# Altium schematic sheet 19WSC_MCU_190726.SchDoc(19WSC_MCU_190726)
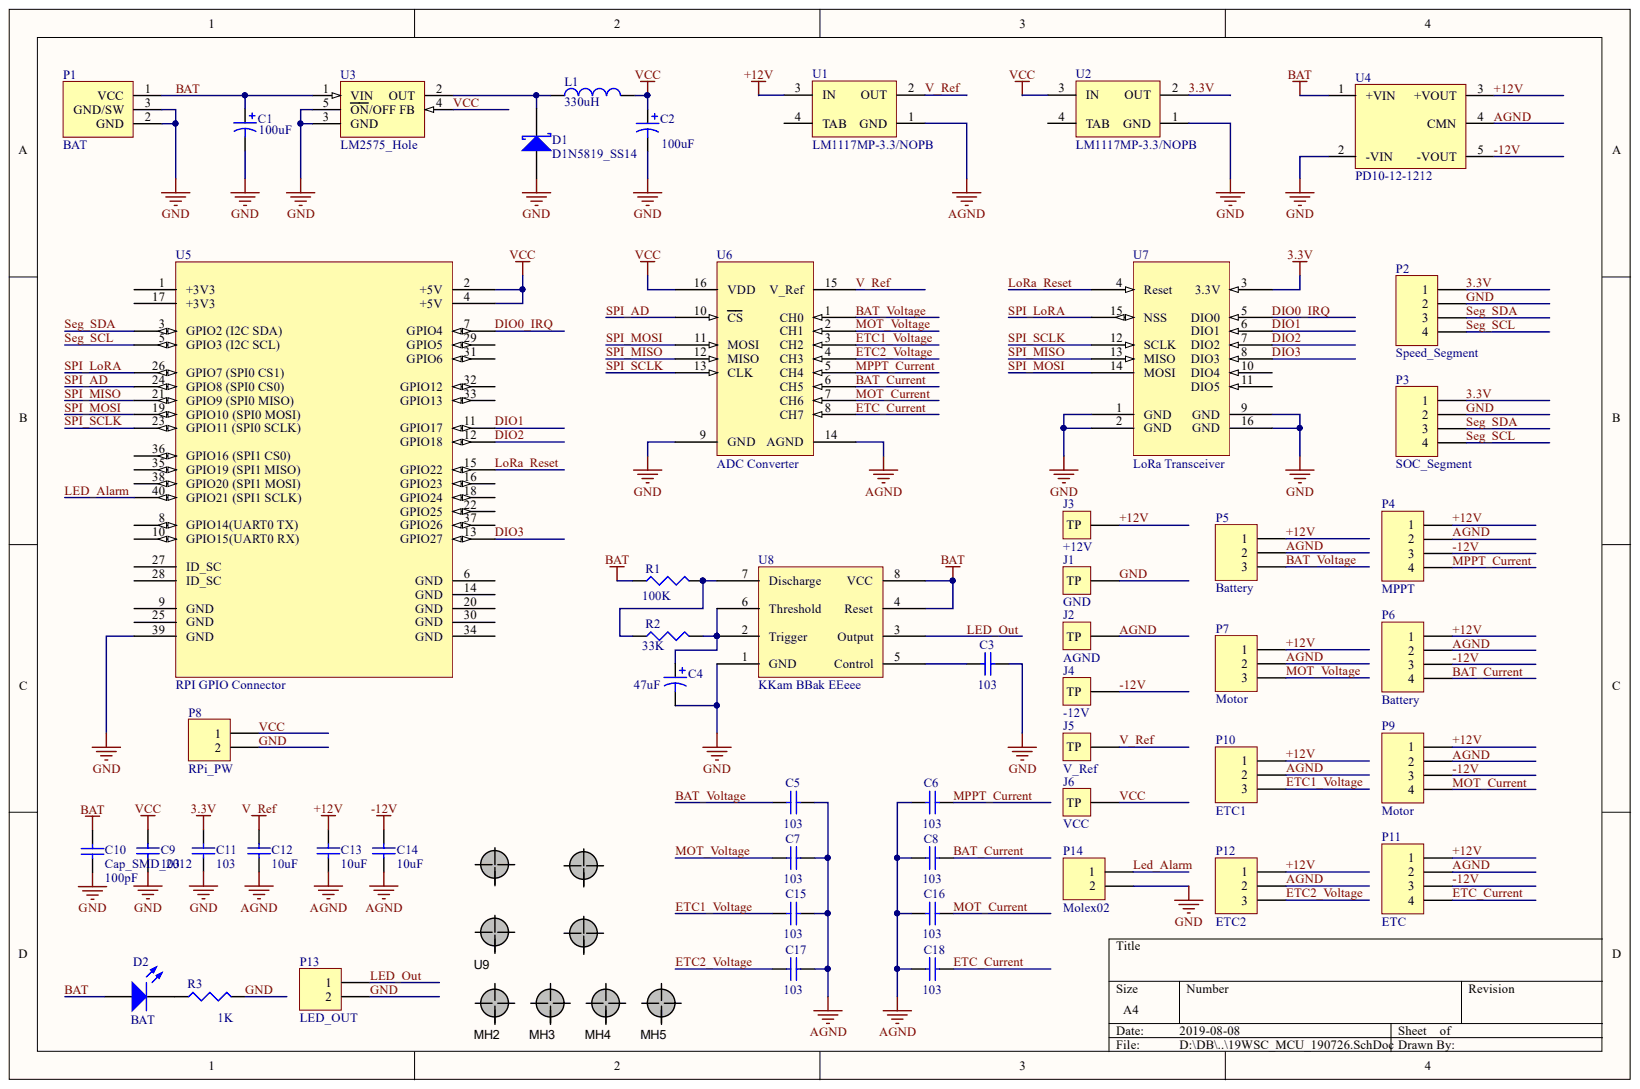
[diagram p0: 19WSC_MCU_190726.SchDoc]

## Parts
C1  value 100uF  [Cap_Pol_SMD_10]  (hidden comment: Cap_Pol_100)
C2  value 100uF  [Cap_Pol_SMD_10]  (hidden comment: Cap_Pol_100)
C3  Cap_SMD_2012  value 103  [CAPC2012N]
C4  value 47uF  [Cap_Pol_SMD_06_3]  (hidden comment: Cap_Pol_10)
C5  Cap_SMD_2012  value 103  [CAPC2012N]
C6  Cap_SMD_2012  value 103  [CAPC2012N]
C7  Cap_SMD_2012  value 103  [CAPC2012N]
C8  Cap_SMD_2012  value 103  [CAPC2012N]
C9  Cap_SMD_2012  value 103  [CAPC2012N]
C10  Cap_SMD_2012  value 100pF  [CAPC2012N]
C11  Cap_SMD_2012  value 103  [CAPC2012N]
C12  Cap_SMD_2012  value 10uF  [CAPC2012N]
C13  Cap_SMD_2012  value 10uF  [CAPC2012N]
C14  Cap_SMD_2012  value 10uF  [CAPC2012N]
C15  Cap_SMD_2012  value 103  [CAPC2012N]
C16  Cap_SMD_2012  value 103  [CAPC2012N]
C17  Cap_SMD_2012  value 103  [CAPC2012N]
C18  Cap_SMD_2012  value 103  [CAPC2012N]
D1  D1N5819_SS14  [Diode_2002]
D2  BAT  [Diode_2012]
J1  GND  [Test_Point]
J2  AGND  [Test_Point]
J3  +12V  [Test_Point]
J4  -12V  [Test_Point]
J5  V_Ref  [Test_Point]
J6  VCC  [Test_Point]
L1  value 330uH  [SMD_Inductor_680]  (hidden comment: Inductor_680)
MH2  Mount_Hole_35  [PCB_Hole_3.5]  (value not drawn)  (no BOM)
MH3  Mount_Hole_35  [PCB_Hole_3.5]  (value not drawn)  (no BOM)
MH4  Mount_Hole_35  [PCB_Hole_3.5]  (value not drawn)  (no BOM)
MH5  Mount_Hole_35  [PCB_Hole_3.5]  (value not drawn)  (no BOM)
P1  BAT  [DC_Jack]
P2  Speed_Segment  [Molex_04]
P3  SOC_Segment  [Molex_04]
P4  MPPT  [Molex_04]
P5  Battery  [Molex_03]
P6  Battery  [Molex_04]
P7  Motor  [Molex_03]
P8  RPi_PW  [Molex_02]
P9  Motor  [Molex_04]
P10  ETC1  [Molex_03]
P11  ETC  [Molex_04]
P12  ETC2  [Molex_03]
P13  LED_OUT  [Molex_02]
P14  Molex02  [Molex_02]
R1  value 100K  [RESC2012N]  (hidden comment: Res_2012)
R2  value 33K  [RESC2012N]  (hidden comment: Res_2012)
R3  value 1K  [RESC2012N]  (hidden comment: Res_2012)
U1  LM1117MP-3.3/NOPB  [LM1117MP-3.3]
U2  LM1117MP-3.3/NOPB  [LM1117MP-3.3]
U3  LM2575_Hole  [LM2575_Hole_Hit]
U4  PD10-12-1212  [PD10-12-1212]
U5  RPI GPIO Connector  Accessory Type: Connector  [40P_PCB_SOCKET_BRD_MNT]
U6  ADC Converter  [DIP_16]
U7  LoRa Transceiver  [LoRa]
U8  KKam BBak EEeee  [SOP_8_M]
U9  RPi3B+_Hole  [RPi3B_Hole]  (value not drawn)  (no BOM)

## Nets
3.3V: C11.2 P2.1 P3.1 U2.2(OUT) U7.3(3.3V)
+12V: C13.2 J3.1 P4.1 P5.1 P6.1 P7.1 P9.1 P10.1 P11.1 P12.1 U1.3(IN) U4.3(+VOUT)
-12V: C14.2 J4.1 P4.3 P6.3 P9.3 P11.3 U4.5(-VOUT)
AGND: C5.2 C6.1 C7.2 C8.1 C12.1 C13.1 C14.1 C15.2 C16.1 C17.2 C18.1 J2.1 P4.2 P5.2 P6.2 P7.2 P9.2 P10.2 P11.2 P12.2 U1.1(GND) U4.4(CMN) U6.14(AGND)
BAT: C1.1 C10.2 D2.1 P1.1 R1.1 U3.1(VIN) U4.1(+VIN) U8.4(Reset) U8.8(VCC)
BAT_CURRENT: C8.2 P6.4 U6.6(CH5)
BAT_VOLTAGE: C5.1 P5.3 U6.1(CH0)
DIO0_IRQ: U5.7(GPIO4) U7.5(DIO0)
DIO1: U5.11(GPIO17) U7.6(DIO1)
DIO2: U5.12(GPIO18) U7.7(DIO2)
DIO3: U5.13(GPIO27) U7.8(DIO3)
ETC1_VOLTAGE: C15.1 P10.3 U6.3(CH2)
ETC2_VOLTAGE: C17.1 P12.3 U6.4(CH3)
ETC_CURRENT: C18.2 P11.4 U6.8(CH7)
GND: C1.2 C2.2 C3.2 C4.2 C9.1 C10.1 C11.1 D1.1 J1.1 P1.2 P1.3 P2.2 P3.2 P8.2 P13.2 P14.2 R3.2 U2.1(GND) U3.3(GND) U3.5(ON/OFF) U4.2(-VIN) U5.39(GND) U6.9(GND) U7.1(GND) U7.2(GND) U7.9(GND) U7.16(GND) U8.1(GND)
LED_ALARM: P14.1 U5.40(GPIO21_(SPI1_SCLK))
LED_OUT: P13.1 U8.3(Output)
LORA_RESET: U5.15(GPIO22) U7.4(Reset)
MOT_CURRENT: C16.2 P9.4 U6.7(CH6)
MOT_VOLTAGE: C7.1 P7.3 U6.2(CH1)
MPPT_CURRENT: C6.2 P4.4 U6.5(CH4)
SEG_SCL: P2.4 P3.4 U5.5(GPIO3_(I2C_SCL))
SEG_SDA: P2.3 P3.3 U5.3(GPIO2_(I2C_SDA))
SPI_AD: U5.24(GPIO8_(SPI0_CS0)) U6.10(CS)
SPI_LORA: U5.26(GPIO7_(SPI0_CS1)) U7.15(NSS)
SPI_MISO: U5.21(GPIO9_(SPI0_MISO)) U6.12(MISO) U7.13(MISO)
SPI_MOSI: U5.19(GPIO10_(SPI0_MOSI)) U6.11(MOSI) U7.14(MOSI)
SPI_SCLK: U5.23(GPIO11_(SPI0_SCLK)) U6.13(CLK) U7.12(SCLK)
V_REF: C12.2 J5.1 U1.2(OUT) U6.15(V_Ref)
VCC: C2.1 C9.2 J6.1 L1.2 P8.1 U2.3(IN) U3.4(FB) U5.2(+5V) U5.4(+5V) U6.16(VDD)
NetC3_1: C3.1 U8.5(Control)
NetC4_1: C4.1 R2.2 U8.2(Trigger) U8.6(Threshold)
NetD1_2: D1.2 L1.1 U3.2(OUT)
NetD2_2: D2.2 R3.1
NetR1_2: R1.2 R2.1 U8.7(Discharge)

Not connected: MH2 1; MH3 1; MH4 1; MH5 1; U1 4(TAB); U2 4(TAB); U5 1(+3V3) 6(GND) 8(GPIO14(UART0_TX)) 9(GND) 10(GPIO15(UART0_RX)) 14(GND) 16(GPIO23) 17(+3V3) 18(GPIO24) 20(GND) 22(GPIO25) 25(GND) 27(ID_SC) 28(ID_SC) 29(GPIO5) 30(GND) 31(GPIO6) 32(GPIO12) 33(GPIO13) 34(GND) 35(GPIO19_(SPI1_MISO)) 36(GPIO16_(SPI1_CS0)) 37(GPIO26) 38(GPIO20_(SPI1_MOSI)); U7 10(DIO4) 11(DIO5); U9 1 2 3 4

## Library data of the parts
C1 C2 = Cap_Pol_100: Polarized Capacitor (SMD 13); Component Kind: Standard; LatestRevisionDate: 17-Jul-2002; LatestRevisionNote: Re-released for DXP Platform.; PackageReference: POLAR0.8; Published: 8-Jun-2000; Library Name: KSH_Library_WSC.IntLib; Publisher: Altium Limited
C3 C5-C18 = Cap_SMD_2012: Capacitor; Component Kind: Standard; LatestRevisionDate: 17-Jul-2002; LatestRevisionNote: Re-released for DXP Platform.; PackageReference: RAD-0.3; Published: 8-Jun-2000; Library Name: KSH_Library_WSC.IntLib; Publisher: Altium Limited
C4 = Cap_Pol_10: Polarized Capacitor (SMD 6.3); Component Kind: Standard; LatestRevisionDate: 17-Jul-2002; LatestRevisionNote: Re-released for DXP Platform.; PackageReference: POLAR0.8; Published: 8-Jun-2000; Library Name: KSH_Library_WSC.IntLib; Publisher: Altium Limited
D1 = D1N5819_SS14: Schottky Rectifier; Note: Pin 2 is not connected and not drawn.; Component Kind: Standard; ComponentLink1Description: Manufacturer Link; ComponentLink1URL: http://www.infineon.com/; ComponentLink2Description: Datasheet; ComponentLink2URL: http://www.infineon.com/upload/Document/cmc_upload/documents/009/363/bat17series.pdf; DatasheetDocument: Oct-1999; PackageDocument: Sep-2001; PackageReference: SOT-23; Published: 21-Mar-2003; Library Name: KSH_Library_WSC.IntLib; Publisher: Altium Limited
D2 = LED_2012: Typical INFRARED GaAs LED; Component Kind: Standard; LatestRevisionDate: 08-Jul-2005; LatestRevisionNote: Mech Layer 1 removed.; PackageReference: LED-0; Published: 8-Jun-2000; Library Name: KSH_Library_WSC.IntLib; Publisher: Altium Limited
J1-J6 = Test_Point: Test Point GND; Component Kind: Standard; Library Name: KSH_Library_WSC.IntLib
L1 = Inductor_680: Code_IEC: 1005; Code_JEITA: 0402; Component Kind: Standard; Description: Inductor_680; LatestRevisionDate: 17-Jul-2002; LatestRevisionNote: Re-released for DXP Platform.; PackageReference: 0402-A; Published: 8-Jun-2000; Library Name: KSH_Library_WSC.IntLib; Publisher: Altium Limited
MH2-MH5 = Mount_Hole_3: Mount Hole 3.5mm; Library Name: KSH_Library_WSC.IntLib
P1 = Battery_Con: Header, 2-Pin; Component Kind: Standard; LatestRevisionDate: 17-Jul-2002; LatestRevisionNote: Re-released for DXP Platform.; Library Name: KSH_Library_WSC.IntLib; Publisher: Altium Limited
P2-P4 P6 P9 P11 = Molex04: Header, 4-Pin; Component Kind: Standard; LatestRevisionDate: 17-Jul-2002; LatestRevisionNote: Re-released for DXP Platform.; Library Name: KSH_Library_WSC.IntLib; Publisher: Altium Limited
P5 P7 P10 P12 = Molex03: Header, 3-Pin; Component Kind: Standard; LatestRevisionDate: 17-Jul-2002; LatestRevisionNote: Re-released for DXP Platform.; Library Name: KSH_Library_WSC.IntLib; Publisher: Altium Limited
P8 P13 P14 = Molex02: Header, 2-Pin; Component Kind: Standard; LatestRevisionDate: 17-Jul-2002; LatestRevisionNote: Re-released for DXP Platform.; Library Name: KSH_Library_WSC.IntLib; Publisher: Altium Limited
R1-R3 = Res_2012: Resistor; Component Kind: Standard; LatestRevisionDate: 04-Mar-2005; LatestRevisionNote: PCB Footprint replaced.; PackageReference: J1-0603; Published: 8-Jun-2000; Library Name: KSH_Library_WSC.IntLib; Publisher: Altium Limited
U1 U2 = LM1117MP-3.3/NOPB: 800mA Low-Dropout Linear Regulator, 4-pin SOT-223, Pb-Free; Manufacturer: National Semiconductor; CompType: LDO IC; PackageTopMarking: XYTT*N05A; PowerWise: N; Code_IPC: SOT223-4; Code_JEDEC: TO-261-AA; Component Kind: Standard; ComponentLink1Description: LM1117 Datasheet; ComponentLink1URL: http://www.national.com/ds/LM/LM1117.pdf; ComponentLink2Description: LM1117 Web Page; ComponentLink2URL: http://www.national.com/pf/LM/LM1117.html; ComponentLink3Description: MP04A Package Page; ComponentLink3URL: http://www.national.com/packaging/folders/mp04a.html; DatasheetVersion: Apr-2006; PackageDescription: Molded SOT-223, 6.50 x 3.56 x 1.6mm Body, 4 Lead, 2.3mm Pitch; PackageReference: MP04A; PackageVersion: revB, Apr-2003; PartNumber: LM1117MP-3.3/NOPB; PinCount: 4; Published: 1-Mar-2010; RoHS: Y; Supplier 1: Digi-Key; Supplier 2: Digi-Key; Supplier 3: Digi-Key; Supplier 4: Farnell; Supplier Part Number 1: LM1117MP-3.3TR-ND; Supplier Part Number 2: LM1117MP-3.3CT-ND; Supplier Part Number 3: LM1117MP-3.3DKR-ND; Supplier Part Number 4: 1469054; Library Name: KSH_Library_WSC.IntLib; Publisher: Altium Limited
U3 = LM2575_Hole: Voltage Regulator; Code_JEDEC: TS-001-AA; Component Kind: Standard; ComponentLink1Description: Manufacturer Link; ComponentLink1URL: http://www.onsemi.com/site/products/taxonomy/0,4438,503,00.html; ComponentLink2Description: Datasheet; ComponentLink2URL: http://www.onsemi.com/pub/Collateral/LM2575-D.PDF; DatasheetVersion: Jul-1999; PackageDescription: TO, Thru-Hole, Vertical, Heatsink Mounted; 5 In-Line Leads; Pitch 1.70 mm; PackageReference: 314D-03; PackageVersion: Jul-1999; Published: 15-Apr-2002; Library Name: KSH_Library_WSC.IntLib; Publisher: Altium Limited
U4 = PD10-12-1212: DC-DC Converter Module; Component Kind: Standard; Published: 02-Sep-2017; Library Name: KSH_Library_WSC.IntLib; Publisher: NSTECH
U5 = RPi3B+: GPIO Header for Raspberry Pi A+/B+/Pi 2/Pi 3 - 2x20; Manufacturer: SparkFun Electronics; Manufacturer Part Number: PRT-14017; Nils Minor: author; nilsminor@web.de: mail; Category: Development Boards, Kits, Programmers; Component Kind: Standard; ComponentLink1Description: https://cdn.sparkfun.com/datasheets/Dev/RaspberryPi/12313.pdf; ComponentLink1URL: https://cdn.sparkfun.com/datasheets/Dev/RaspberryPi/12313.pdf; For Use With/Related Products: Raspberry Pi; Part Status: Active; Pricing 1: 1=1.84 (EUR); RoHS: RoHS Compliant; Stock 1: 150; Library Name: KSH_Library_WSC.IntLib
U6 = MCP3208: Manufacturer: SparkFun Electronics; Manufacturer Part Number: PRT-14017; Accessory Type: Connector; For Use With/Related Products: Raspberry Pi; Nils Minor: author; nilsminor@web.de: mail; Category: Development Boards, Kits, Programmers; Component Kind: Standard; ComponentLink1Description: https://cdn.sparkfun.com/datasheets/Dev/RaspberryPi/12313.pdf; ComponentLink1URL: https://cdn.sparkfun.com/datasheets/Dev/RaspberryPi/12313.pdf; Description: ADC Converter; Part Status: Active; Pricing 1: 1=1.84 (EUR); RoHS: RoHS Compliant; Stock 1: 150; Library Name: KSH_Library_WSC.IntLib
U7 = SX1278: Manufacturer: SparkFun Electronics; Manufacturer Part Number: PRT-14017; Accessory Type: Connector; For Use With/Related Products: Raspberry Pi; Nils Minor: author; nilsminor@web.de: mail; Category: Development Boards, Kits, Programmers; Component Kind: Standard; ComponentLink1Description: https://cdn.sparkfun.com/datasheets/Dev/RaspberryPi/12313.pdf; ComponentLink1URL: https://cdn.sparkfun.com/datasheets/Dev/RaspberryPi/12313.pdf; Description: LoRa Transceiver; Part Status: Active; Pricing 1: 1=1.84 (EUR); RoHS: RoHS Compliant; Stock 1: 150; Library Name: KSH_Library_WSC.IntLib
U8 = SE555: Manufacturer: SparkFun Electronics; Manufacturer Part Number: PRT-14017; Accessory Type: Connector; For Use With/Related Products: Raspberry Pi; Nils Minor: author; nilsminor@web.de: mail; Category: Development Boards, Kits, Programmers; Component Kind: Standard; ComponentLink1Description: https://cdn.sparkfun.com/datasheets/Dev/RaspberryPi/12313.pdf; ComponentLink1URL: https://cdn.sparkfun.com/datasheets/Dev/RaspberryPi/12313.pdf; Description: KKam BBak EEeee; Part Status: Active; Pricing 1: 1=1.84 (EUR); RoHS: RoHS Compliant; Stock 1: 150; Library Name: KSH_Library_WSC.IntLib
U9 = RPi3B+_Hole: Description: RPi3B+_Hole; Library Name: KSH_Library_WSC.IntLib
